# Altium schematic sheet SPO2.SchDoc
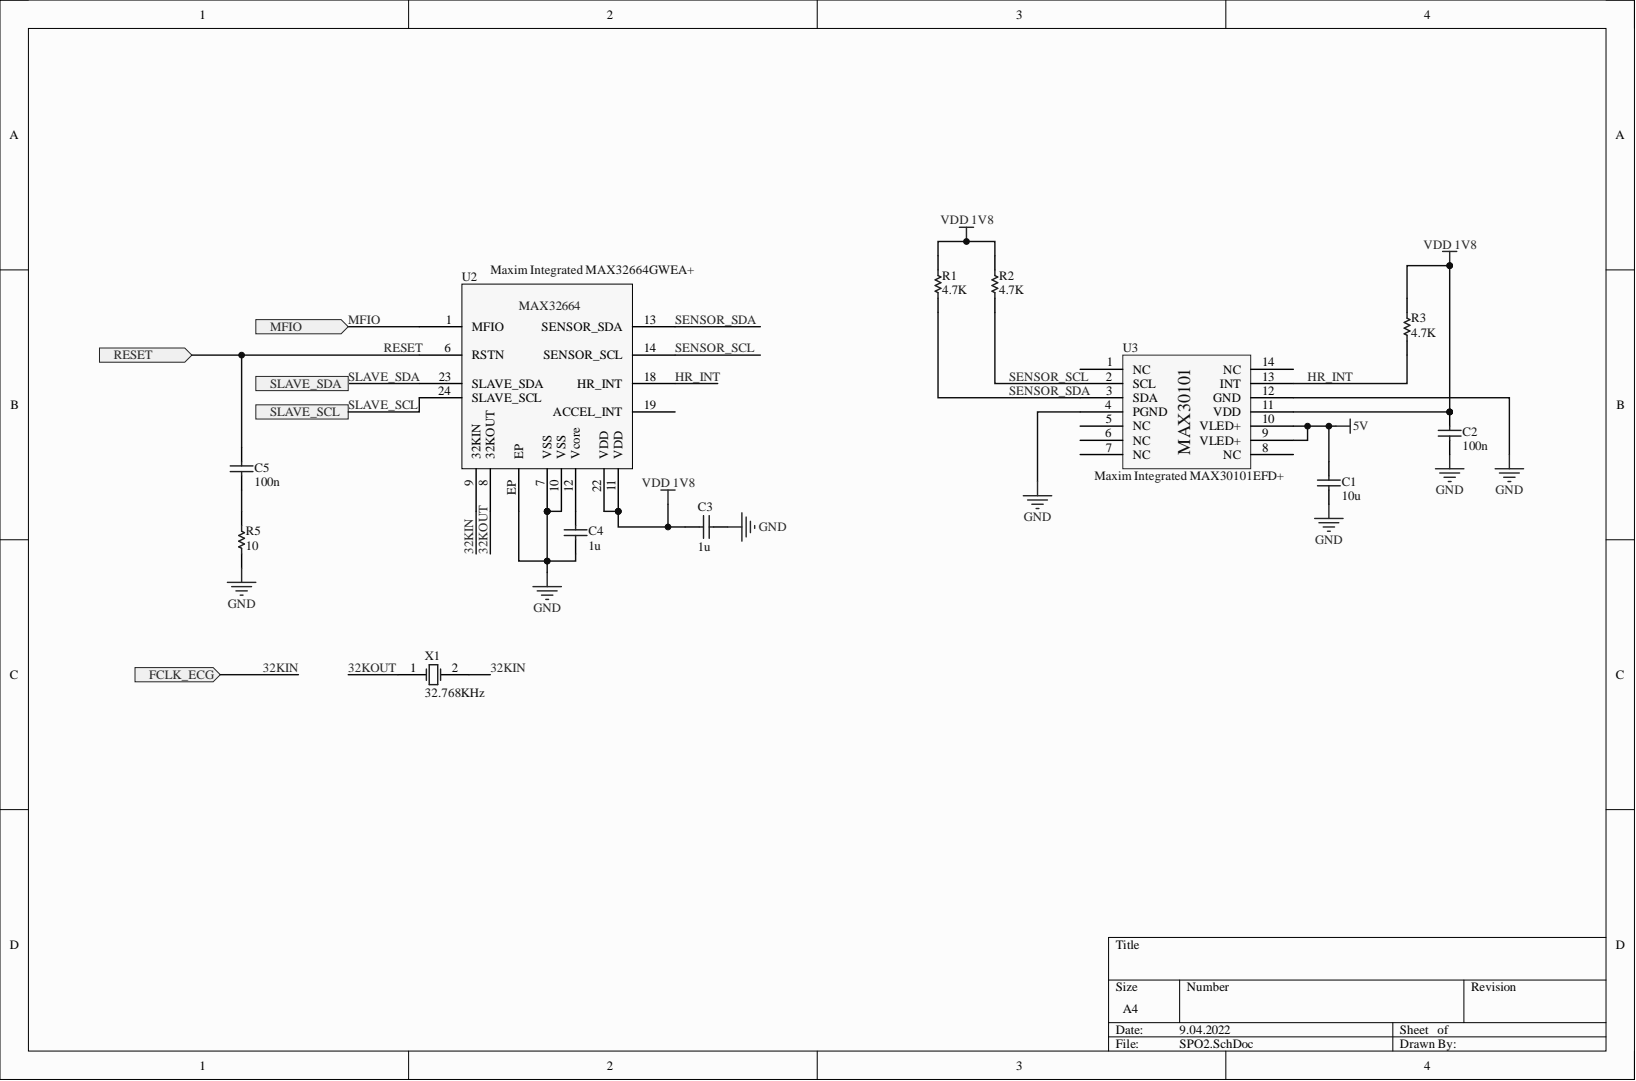
[diagram p0: SPO2.SchDoc]

## Parts
C1  10u  [CAPC1005X60X30LL05T10]
C2  100n  [CAPC1005X60X30LL05T10]
C3  1u  [CAPC1005X60X30LL05T10]
C4  1u  [CAPC1005X60X30LL05T10]
C5  100n  [CAPC1005X60X30LL05T10]
R1  4.7K  [RESC1005X40X25NL05T05]
R2  4.7K  [RESC1005X40X25NL05T05]
R3  4.7K  [RESC1005X40X25NL05T05]
R5  10  [RESC1005X37X25ML05T10]
U2  Maxim Integrated MAX32664GWEA+  Manufacturer Part Number: MAX32664GWEA+  [PCB-9w0dyd6po6ll56i1kcwj-1]
U3  Maxim Integrated MAX30101EFD+  Manufacturer Part Number: MAX30101EFD+  [Quad14]
X1  32.768KHz  [PCB-jrdwn9qpfk2qy1hmuw7r-1]

## Ports
FCLK_ECG, MFIO, RESET, SLAVE_SCL, SLAVE_SDA

## Nets
5V: C1.1 U3.9(VLED+) U3.10(VLED+)
GND: C1.2 C2.2 C3.2 C4.2 R5.1 U2.7(VSS) U2.10(VSS) U2.EP U3.4(PGND) U3.12(GND)
VDD 1V8: C2.1 C3.1 R1.2 R2.2 R3.2 U2.11(VDD) U2.22(VDD) U3.11(VDD)
32KIN: U2.9(32KIN) X1.2 ; port FCLK_ECG
32KOUT: U2.8(32KOUT) X1.1
HR_INT: R3.1 U2.18(HR_INT) U3.13(INT)
MFIO: U2.1(MFIO) ; port MFIO
RESET: C5.1 U2.6(RSTN) ; port RESET
SENSOR_SCL: R2.1 U2.14(SENSOR_SCL) U3.2(SCL)
SENSOR_SDA: R1.1 U2.13(SENSOR_SDA) U3.3(SDA)
SLAVE_SCL: U2.24(SLAVE_SCL) ; port SLAVE_SCL
SLAVE_SDA: U2.23(SLAVE_SDA) ; port SLAVE_SDA
NetC4_1: C4.1 U2.12(Vcore)
NetC5_2: C5.2 R5.2

Not connected: U2 19(ACCEL_INT); U3 1(NC) 5(NC) 6(NC) 7(NC) 8(NC) 14(NC)

## Library data of the parts
C1-C5 = CMP-3a10f0da043ee5d7-2: Cap Cer 1uf 25v 10% X5r 0402; Component Kind: Standard; Library Name: CommunityVault
R1-R3 = CMP-e02329a4a0913fe4-1: Res Thick Film 0402 4.7K Ohm 1% 0.1W(1/10W) ±100ppm/C Molded SMD Automotive Punched T/R; Manufacturer Part Number: ERJ-2RKF4701X; Lead-Free Status: Lead Free; Power Rating: 100 mW; Resistance: 4.70 kΩ; Voltage Rating (DC): 50.0 V; Case/Package: 0402; Component Kind: Standard; Library Name: CommunityVault; Lifecycle Status: Not Listed by Manufacturer; Mounting Style: Surface Mount; Number of Pins: 2; Octopart URL: http://octopart.com/erj-2rkf4701x-panasonic-9266644; OctopartID: e02329a4a0913fe4; Packaging: Tape & Reel (TR), Cut Tape (CT); REACH SVHC Compliance: No SVHC; Resistance Tolerance: ±1%; RoHS: Compliant
R5 = CMP-7834186-2: Thick Film Resistors - SMD 1/16W 10ohm 1%; Manufacturer Part Number: AC0402FR-0710RL; Lead-Free Status: Lead Free; Resistance: 10.0 Ω; Case/Package: 0402; Component Kind: Standard; Library Name: CommunityVault; Mounting Style: Surface Mount; Octopart Url: https://octopart.com/ac0402fr-0710rl-yageo-24618143; OctopartID: 12a3dade935acd64; Resistance Tolerance: ±1%; RoHS: Compliant
U2 = CMP-0ed4c2a453a4d113-7: Ultra Low Power Biometric Sensor Hub 1.8V 16-Bump WLP T/R; Supply Voltage (DC): 1.71 V (min), 3.63 V (max); Component Kind: Standard; Library Name: FavoritesLibrary; Lifecycle Status: Not Listed by Manufacturer; Octopart URL: https://octopart.com/max32664gwea%2B-maxim+integrated-91912214; OctopartID: 0ed4c2a453a4d113; Operating Temperature: -40.0 °C (min); RoHS: Compliant
U3 = CMP-9bd551b92ace4412-9: Integrated Optical Sensor; Lead-Free Status: Lead Free; Supply Voltage (DC): 1.70 V (min), 2.00 V (max); Component Kind: Standard; Library Name: FavoritesLibrary; Lifecycle Status: Not Listed by Manufacturer; Octopart URL: https://octopart.com/max30101efd%2B-maxim+integrated-57054333; OctopartID: 9bd551b92ace4412; Operating Temperature: -40.0 °C (min); RoHS: Compliant
X1 = CMP-25efed2ad924fa28-2: Crystal 0.032768MHz ±20ppm (Tol) ±250ppm (Stability) 4.1pF Flexural 80000Ohm 2-Pin CSMD T/R; Manufacturer Part Number: ABS06-107-32.768KHZ-T; Lead-Free Status: Lead Free; Load Capacitance: 4.00 pF; Component Kind: Standard; Library Name: CommunityVault; Mounting Style: Surface Mount; Number of Pins: 2; Octopart URL: https://octopart.com/abs06-107-32.768khz-t-abracon-30398893; RoHS: Compliant
